# Altium schematic sheet 主控板A.SchDoc
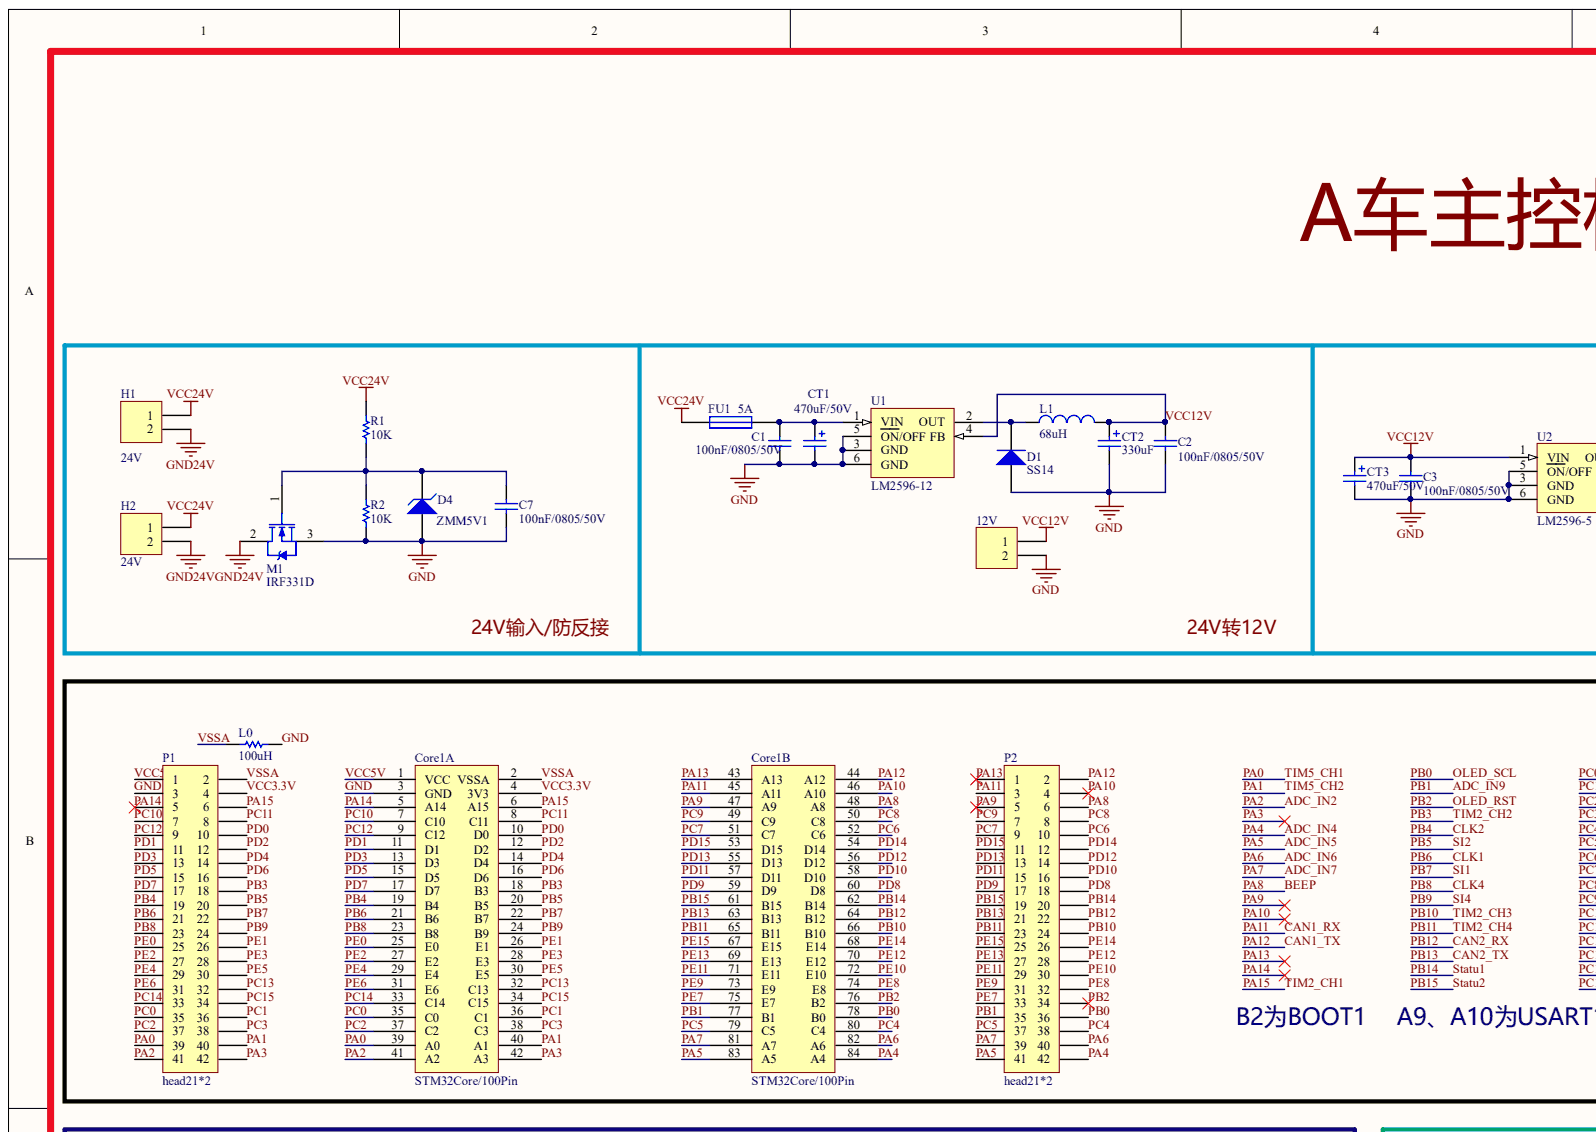
[diagram p0: 主控板A.SchDoc, top left]
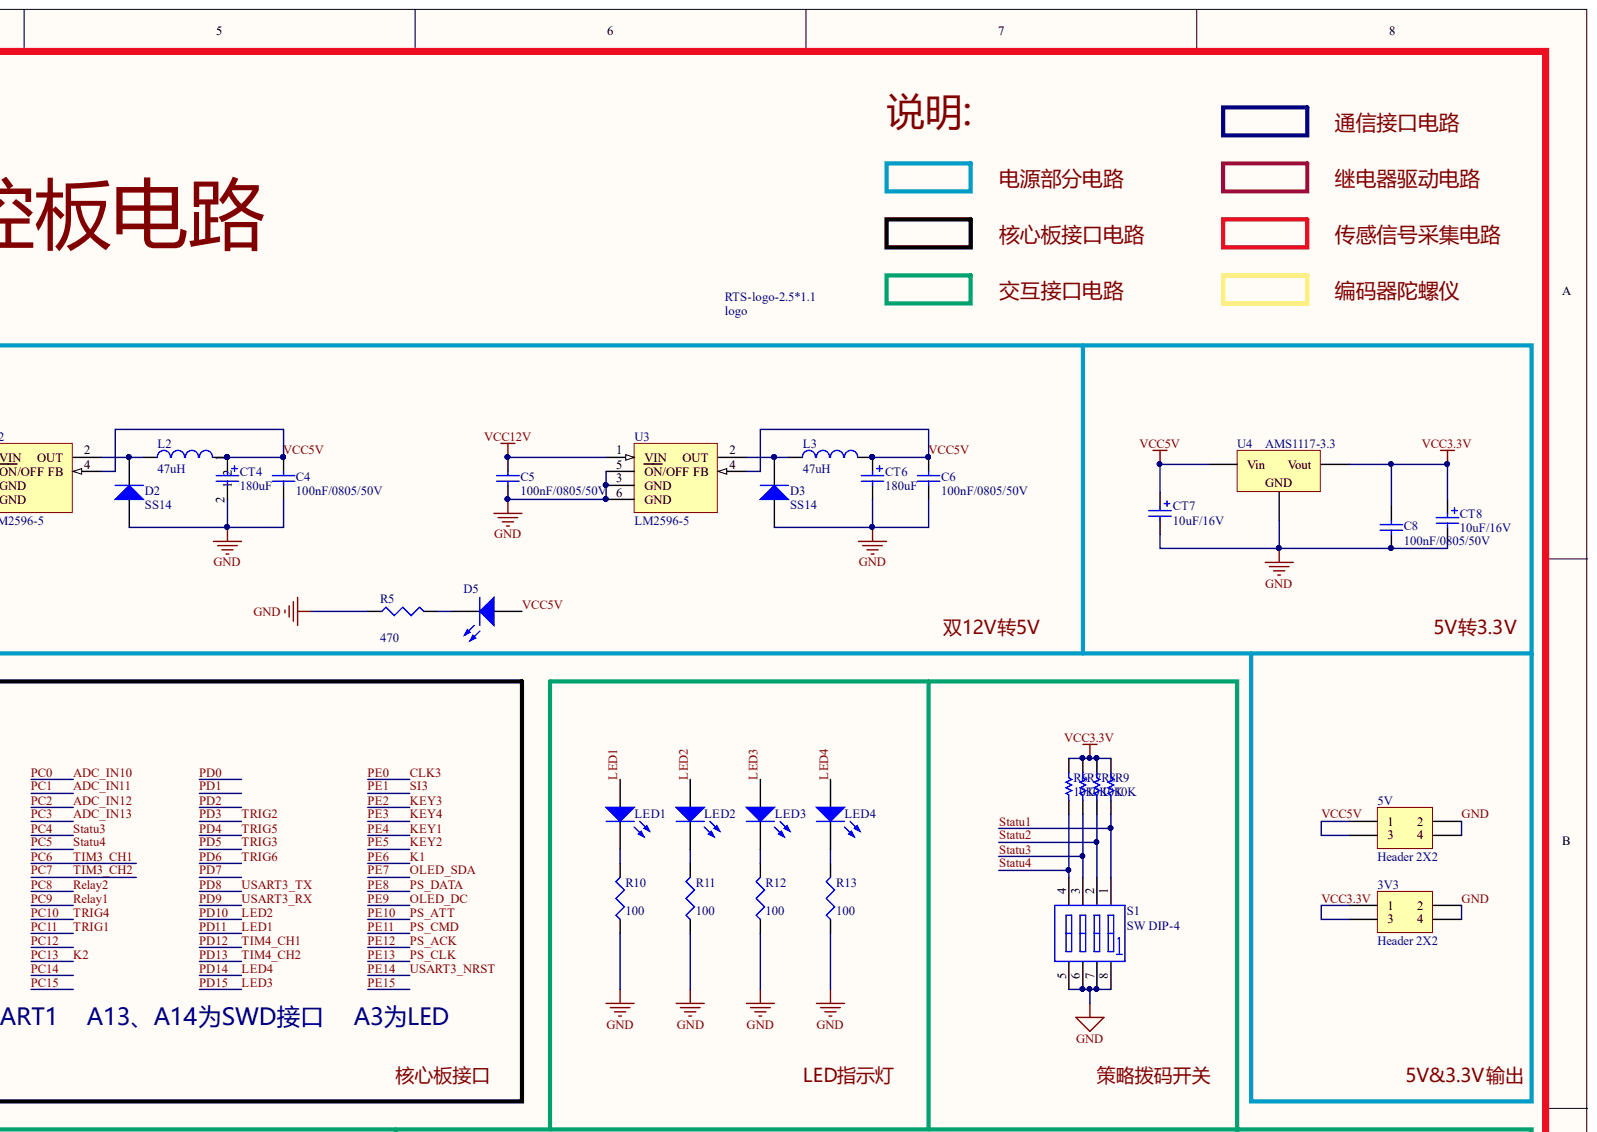
[diagram p1: 主控板A.SchDoc, top right]
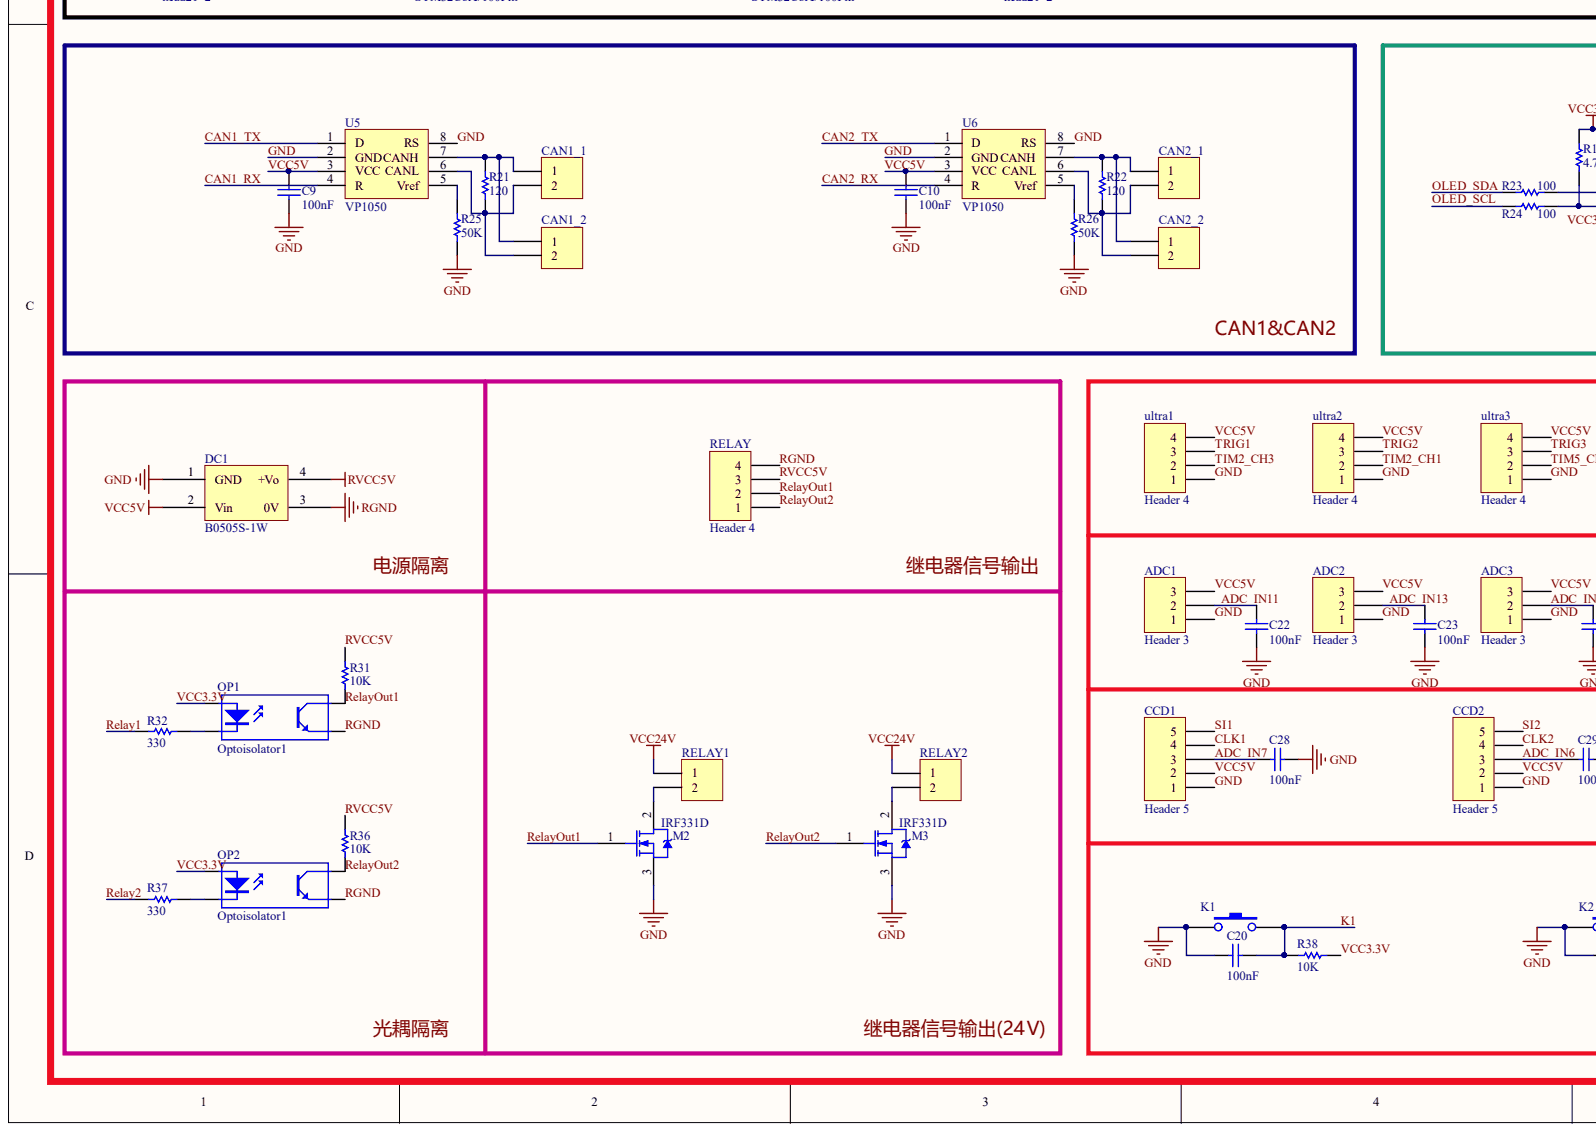
[diagram p2: 主控板A.SchDoc, bottom left]
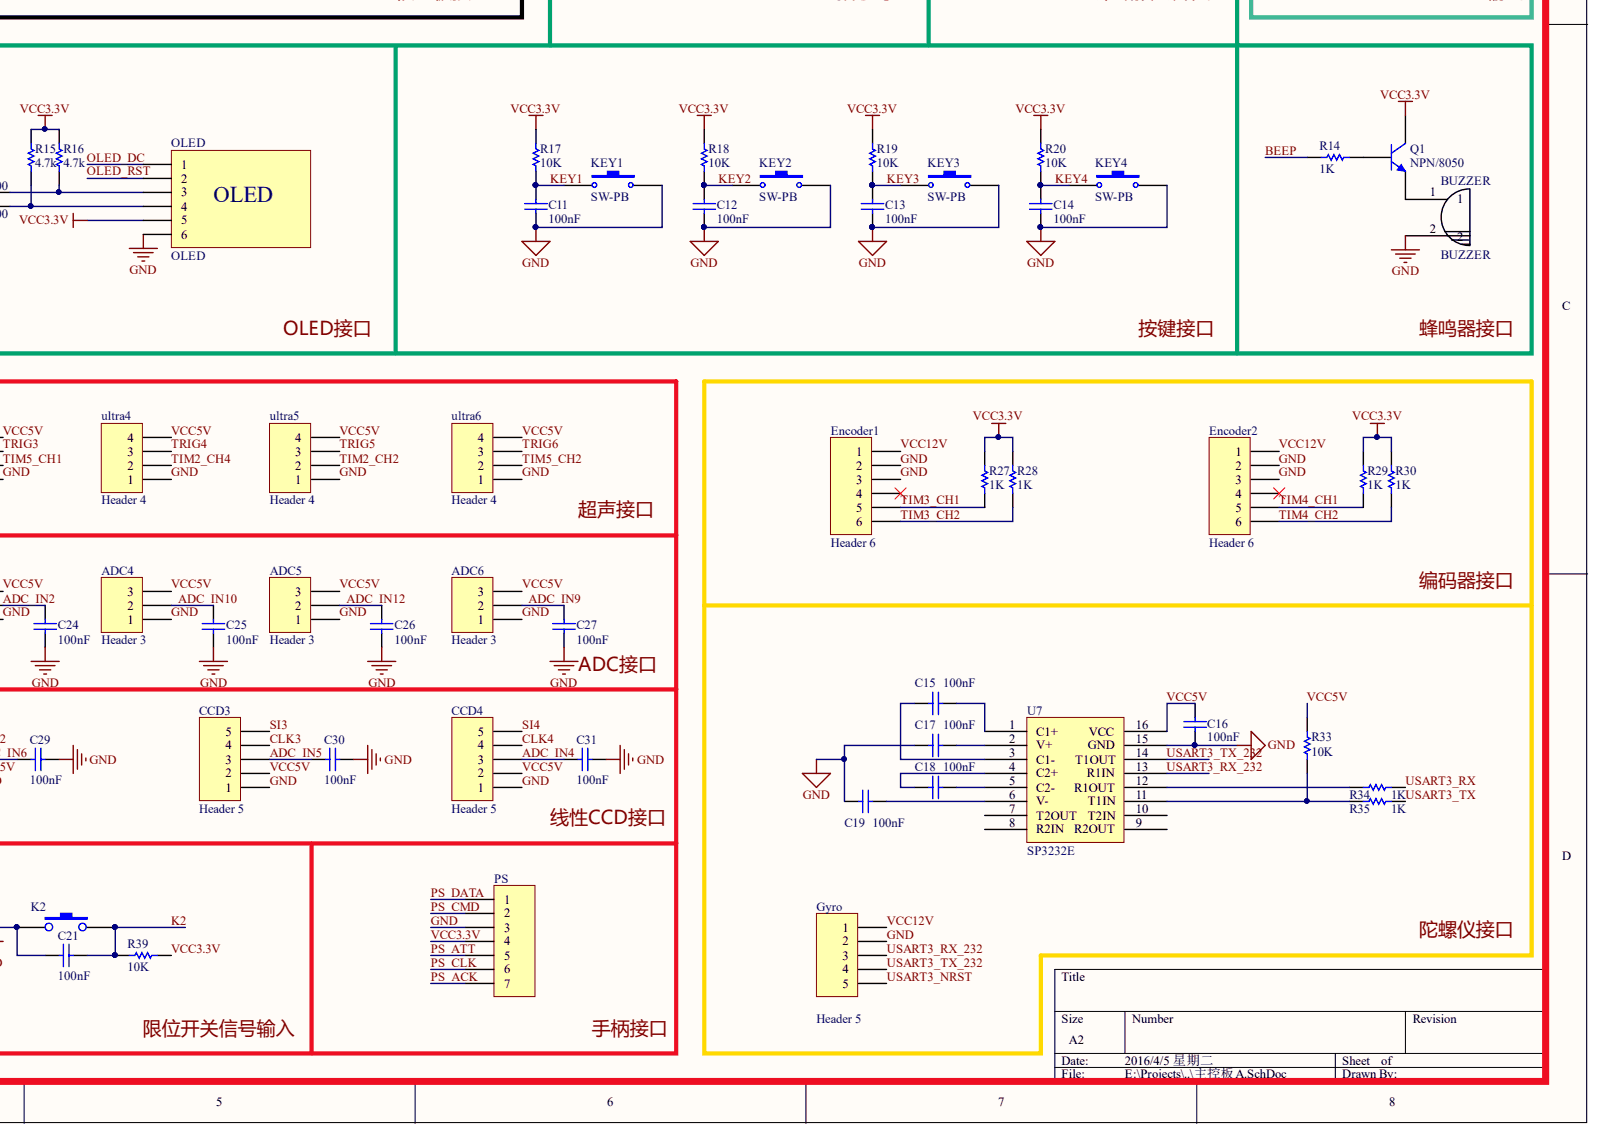
[diagram p3: 主控板A.SchDoc, bottom right]

## Parts
3V3  Header 2X2  [HDR2X2]
5V  Header 2X2  [HDR2X2]
12V  Header 2  [7555]  (value not drawn)
ADC1  Header 3  [H3]
ADC2  Header 3  [H3]
ADC3  Header 3  [H3]
ADC4  Header 3  [H3]
ADC5  Header 3  [H3]
ADC6  Header 3  [H3]
BUZZER  BUZZER  [BUZZER_DIP]
C1  100nF/0805/50V  [0805C]
C2  100nF/0805/50V  [0805C]
C3  100nF/0805/50V  [0805C]
C4  100nF/0805/50V  [0805C]
C5  100nF/0805/50V  [0805C]
C6  100nF/0805/50V  [0805C]
C7  100nF/0805/50V  [0805C]
C8  100nF/0805/50V  [0805C]
C9  100nF  [0805C]
C10  100nF  [0805C]
C11  value 100nF  [0805C]  (hidden comment: Cap)
C12  value 100nF  [0805C]  (hidden comment: Cap)
C13  value 100nF  [0805C]  (hidden comment: Cap)
C14  value 100nF  [0805C]  (hidden comment: Cap)
C15  value 100nF  [0805C]  (hidden comment: Cap)
C16  value 100nF  [0805C]  (hidden comment: Cap)
C17  value 100nF  [0805C]  (hidden comment: Cap)
C18  value 100nF  [0805C]  (hidden comment: Cap)
C19  value 100nF  [0805C]  (hidden comment: Cap)
C20  100nF  [0805C]
C21  100nF  [0805C]
C22  100nF  [0805C]
C23  100nF  [0805C]
C24  100nF  [0805C]
C25  100nF  [0805C]
C26  100nF  [0805C]
C27  100nF  [0805C]
C28  100nF  [0805C]
C29  100nF  [0805C]
C30  100nF  [0805C]
C31  100nF  [0805C]
CAN1_1  Header 2  [H2]  (value not drawn)
CAN1_2  Header 2  [H2]  (value not drawn)
CAN2_1  Header 2  [H2]  (value not drawn)
CAN2_2  Header 2  [H2]  (value not drawn)
CCD1  Header 5  [H5]
CCD2  Header 5  [H5]
CCD3  Header 5  [H5]
CCD4  Header 5  [H5]
Core1  STM32Core/100Pin  [Core]  (parts A,B)
CT1  470uF/50V  [LV_Cap8*8mm]
CT2  330uF  [LV_Cap8*8mm]
CT3  470uF/50V  [LV_Cap8*8mm]
CT4  180uF  [LV_Cap8*8mm]
CT6  180uF  [LV_Cap8*8mm]
CT7  10uF/16V  [0805C]
CT8  10uF/16V  [0805C]
D1  SS14  [SS12-SS100/SMA]
D2  SS14  [SS12-SS100/SMA]
D3  SS14  [SS12-SS100/SMA]
D4  ZMM5V1  [ZMM2V4-ZMM75]
D5  (no value)  [LED0805]
DC1  B0505S-1W  [B_S-1W]
Encoder1  Header 6  [H6]
Encoder2  Header 6  [H6]
FU1  value 5A  [UF500]  (hidden comment: FUSE)
Gyro  Header 5  [H5]
H1  24V  [7555]
H2  24V  [7555]
K1  RESET  [HDR1X2]  (value not drawn)
K2  RESET  [HDR1X2]  (value not drawn)
KEY1  SW-PB  [KEY_SMD]
KEY2  SW-PB  [KEY_SMD]
KEY3  SW-PB  [KEY_SMD]
KEY4  SW-PB  [KEY_SMD]
L0  100uH  [0805R]
L1  value 68uH  [INDC2_100UH]  (hidden comment: Inductor)
L2  value 47uH  [INDC2_100UH]  (hidden comment: Inductor)
L3  value 47uH  [INDC2_100UH]  (hidden comment: Inductor)
LED1  (no value)  [LED0805]
LED2  (no value)  [LED0805]
LED3  (no value)  [LED0805]
LED4  (no value)  [LED0805]
logo  RTSlogo2.5*1.1  [logo_2.5*1.1]
M1  IRF331D  [TO263]
M2  IRF331D  [IRLR7843]
M3  IRF331D  [IRLR7843]
OLED  OLED  [OLED]
OP1  Optoisolator1  [G3VM-61D1]
OP2  Optoisolator1  [G3VM-61D1]
P1  head21*2  [head21*2]
P2  head21*2  [head21*2]
PS  Header 7  [H7]  (value not drawn)
Q1  NPN/8050  [SOT-23_L]
R1  10K  [0805R]
R2  10K  [0805R]
R5  value 470  [0805R]
R6  10K  [0805R]
R7  10K  [0805R]
R8  10K  [0805R]
R9  10K  [0805R]
R10  value 100  [0805R]
R11  value 100  [0805R]
R12  value 100  [0805R]
R13  value 100  [0805R]
R14  1K  [0805R]
R15  4.7k  [0805R]
R16  4.7k  [0805R]
R17  value 10K  [0805R]  (hidden comment: Res1)
R18  value 10K  [0805R]  (hidden comment: Res1)
R19  value 10K  [0805R]  (hidden comment: Res1)
R20  value 10K  [0805R]  (hidden comment: Res1)
R21  120  [0805R]
R22  120  [0805R]
R23  100  [0805R]
R24  100  [0805R]
R25  50K  [0805R]
R26  50K  [0805R]
R27  1K  [0805R]
R28  1K  [0805R]
R29  1K  [0805R]
R30  1K  [0805R]
R31  10K  [0805R]
R32  330  [0805R]
R33  10K  [0805R]
R34  1K  [0805R]
R35  1K  [0805R]
R36  10K  [0805R]
R37  330  [0805R]
R38  10K  [0805R]
R39  10K  [0805R]
RELAY  Header 4  [H4]
RELAY1  Header 2  [7555]  (value not drawn)
RELAY2  Header 2  [7555]  (value not drawn)
S1  SW DIP-4  [BOMA]
U1  LM2596-12  [LM2596]
U2  LM2596-5  [LM2596]
U3  LM2596-5  [LM2596]
U4  AMS1117-3.3  [SOT223]
U5  VP1050  [SO8M]
U6  VP1050  [SO8M]
U7  SP3232E  [SOP16 MAX232]
ultra1  Header 4  [H4]
ultra2  Header 4  [H4]
ultra3  Header 4  [H4]
ultra4  Header 4  [H4]
ultra5  Header 4  [H4]
ultra6  Header 4  [H4]

## Nets
GND24V: H1.2 H2.2 M1.2
VCC24V: FU1.1 H1.1 H2.1 R1.2 RELAY1.1 RELAY2.1
ADC_IN2: ADC3.2 C24.2 Core1.41(A2) P1.41
ADC_IN4: C31.2 CCD4.3 Core1.84(A4) P2.42
ADC_IN5: C30.2 CCD3.3 Core1.83(A5) P2.41
ADC_IN6: C29.2 CCD2.3 Core1.82(A6) P2.40
ADC_IN7: C28.2 CCD1.3 Core1.81(A7) P2.39
ADC_IN9: ADC6.2 C27.2 Core1.77(B1) P2.35
ADC_IN10: ADC4.2 C25.2 Core1.35(C0) P1.35
ADC_IN11: ADC1.2 C22.2 Core1.36(C1) P1.36
ADC_IN12: ADC5.2 C26.2 Core1.37(C2) P1.37
ADC_IN13: ADC2.2 C23.2 Core1.38(C3) P1.38
BEEP: Core1.48(A8) P2.6 R14.1
CAN1_RX: Core1.45(A11) P2.3 U5.4(R)
CAN1_TX: Core1.44(A12) P2.2 U5.1(D)
CAN2_RX: Core1.64(B12) P2.22 U6.4(R)
CAN2_TX: Core1.63(B13) P2.21 U6.1(D)
CLK1: CCD1.4 Core1.21(B6) P1.21
CLK2: CCD2.4 Core1.19(B4) P1.19
CLK3: CCD3.4 Core1.25(E0) P1.25
CLK4: CCD4.4 Core1.23(B8) P1.23
GND: 3V3.2 3V3.4 5V.2 5V.4 12V.2 ADC1.1 ADC2.1 ADC3.1 ADC4.1 ADC5.1 ADC6.1 BUZZER.2 C1.1 C2.1 C3.1 C4.1 C5.1 C6.1 C7.1 C8.1 C9.1 C10.1 C11.1 C12.1 C13.1 C14.1 C16.1 C17.2 C19.2 C20.1 C21.1 C22.1 C23.1 C24.1 C25.1 C26.1 C27.1 C28.1 C29.1 C30.1 C31.1 CCD1.1 CCD2.1 CCD3.1 CCD4.1 Core1.3(GND) CT1.2 CT2.2 CT3.2 CT4.2 CT6.2 CT7.2 CT8.2 D1.1 D2.1 D3.1 D4.1 DC1.1(GND) Encoder1.2 Encoder1.3 Encoder2.2 Encoder2.3 Gyro.2 K1.1 K2.1 KEY1.2 KEY2.2 KEY3.2 KEY4.2 L0.1 M1.3 M2.3 M3.3 OLED.6 P1.3 PS.3 R2.1 R5.1 R10.1 R11.1 R12.1 R13.1 R25.1 R26.1 S1.5 S1.6 S1.7 S1.8 U1.3(GND) U1.5(ON/OFF) U1.6(GND) U2.3(GND) U2.5(ON/OFF) U2.6(GND) U3.3(GND) U3.5(ON/OFF) U3.6(GND) U4.1 U5.2(GND) U5.8(RS) U6.2(GND) U6.8(RS) U7.15(GND) ultra1.1 ultra2.1 ultra3.1 ultra4.1 ultra5.1 ultra6.1
K1: C20.2 Core1.31(E6) K1.2 P1.31 R38.1
K2: C21.2 Core1.32(C13) K2.2 P1.32 R39.1
KEY1: C11.2 Core1.29(E4) KEY1.1 P1.29 R17.2
KEY2: C12.2 Core1.30(E5) KEY2.1 P1.30 R18.2
KEY3: C13.2 Core1.27(E2) KEY3.1 P1.27 R19.2
KEY4: C14.2 Core1.28(E3) KEY4.1 P1.28 R20.2
LED1: Core1.57(D11) LED1.1 P2.15
LED2: Core1.58(D10) LED2.1 P2.16
LED3: Core1.53(D15) LED3.1 P2.11
LED4: Core1.54(D14) LED4.1 P2.12
OLED_DC: Core1.73(E9) OLED.1 P2.31
OLED_RST: Core1.76(B2) OLED.2 P2.34
OLED_SCL: Core1.78(B0) P2.36 R24.2
OLED_SDA: Core1.75(E7) P2.33 R23.2
PA0: Core1.39(A0) P1.39 ultra3.2
PA1: Core1.40(A1) P1.40 ultra6.2
PA3: Core1.42(A3) P1.42
PA9: Core1.47(A9) P2.5
PA10: Core1.46(A10) P2.4
PA13: Core1.43(A13) P2.1
PA14: Core1.5(A14) P1.5
PA15: Core1.6(A15) P1.6 ultra2.2
PB3: Core1.18(B3) P1.18 ultra5.2
PB5: CCD2.5 Core1.20(B5) P1.20
PB7: CCD1.5 Core1.22(B7) P1.22
PB9: CCD4.5 Core1.24(B9) P1.24
PB10: Core1.66(B10) P2.24 ultra1.2
PB11: Core1.65(B11) P2.23 ultra4.2
PB14: Core1.62(B14) P2.20 R9.2 S1.1
PB15: Core1.61(B15) P2.19 R8.2 S1.2
PC4: Core1.80(C4) P2.38 R7.2 S1.3
PC5: Core1.79(C5) P2.37 R6.2 S1.4
PC6: Core1.52(C6) Encoder1.5 P2.10 R27.2
PC7: Core1.51(C7) Encoder1.6 P2.9 R28.2
PC8: Core1.50(C8) P2.8 R37.2
PC9: Core1.49(C9) P2.7 R32.2
PC10: Core1.7(C10) P1.7 ultra4.3
PC11: Core1.8(C11) P1.8 ultra1.3
PC12: Core1.9(C12) P1.9
PC14: Core1.33(C14) P1.33
PC15: Core1.34(C15) P1.34
PD0: Core1.10(D0) P1.10
PD1: Core1.11(D1) P1.11
PD2: Core1.12(D2) P1.12
PD3: Core1.13(D3) P1.13 ultra2.3
PD4: Core1.14(D4) P1.14 ultra5.3
PD5: Core1.15(D5) P1.15 ultra3.3
PD6: Core1.16(D6) P1.16 ultra6.3
PD7: Core1.17(D7) P1.17
PD8: Core1.60(D8) P2.18 R35.1
PD9: Core1.59(D9) P2.17 R34.1
PD12: Core1.56(D12) Encoder2.5 P2.14 R29.2
PD13: Core1.55(D13) Encoder2.6 P2.13 R30.2
PE1: CCD3.5 Core1.26(E1) P1.26
PE8: Core1.74(E8) P2.32 PS.1
PE10: Core1.72(E10) P2.30 PS.5
PE11: Core1.71(E11) P2.29 PS.2
PE12: Core1.70(E12) P2.28 PS.7
PE13: Core1.69(E13) P2.27 PS.6
PE14: Core1.68(E14) Gyro.5 P2.26
PE15: Core1.67(E15) P2.25
RELAYOUT1: M2.1 OP1.4 R31.2 RELAY.2
RELAYOUT2: M3.1 OP2.4 R36.2 RELAY.1
RGND: DC1.3(0V) OP1.3 OP2.3 RELAY.4
RVCC5V: DC1.4(+Vo) R31.1 R36.1 RELAY.3
USART3_RX_232: Gyro.3 U7.13(R1IN)
USART3_TX_232: Gyro.4 U7.14(T1OUT)
VCC3.3V: 3V3.1 3V3.3 C8.2 Core1.4(3V3) CT8.1 OLED.5 OP1.1 OP2.1 P1.4 PS.4 Q1.1 R6.1 R7.1 R8.1 R9.1 R15.2 R16.2 R17.1 R18.1 R19.1 R20.1 R27.1 R28.1 R29.1 R30.1 R38.2 R39.2 U4.2
VCC5V: 5V.1 5V.3 ADC1.3 ADC2.3 ADC3.3 ADC4.3 ADC5.3 ADC6.3 C4.2 C6.2 C9.2 C10.2 C16.2 CCD1.2 CCD2.2 CCD3.2 CCD4.2 Core1.1(VCC) CT4.1 CT6.1 CT7.1 D5.1 DC1.2(Vin) L2.2 L3.2 P1.1 R33.1 U2.4(FB) U3.4(FB) U4.3 U5.3(VCC) U6.3(VCC) U7.16(VCC) ultra1.4 ultra2.4 ultra3.4 ultra4.4 ultra5.4 ultra6.4
VCC12V: 12V.1 C2.2 C3.2 C5.2 CT2.1 CT3.1 Encoder1.1 Encoder2.1 Gyro.1 L1.2 U1.4(FB) U2.1(VIN) U3.1(VIN)
VSSA: Core1.2(VSSA) L0.2 P1.2
NetBUZZER_1: BUZZER.1 Q1.3
NetC1_2: C1.2 CT1.1 FU1.2 U1.1(VIN)
NetC7_2: C7.2 D4.2 M1.1 R1.1 R2.2
NetC15_1: C15.1 U7.1(C1+)
NetC15_2: C15.2 U7.3(C1-)
NetC17_1: C17.1 U7.2(V+)
NetC18_1: C18.1 U7.5(C2-)
NetC18_2: C18.2 U7.4(C2+)
NetC19_1: C19.1 U7.6(V-)
NetCAN1_1_1: CAN1_1.1 CAN1_2.1 R21.2 U5.7(CANH)
NetCAN1_1_2: CAN1_1.2 CAN1_2.2 R21.1 U5.6(CANL)
NetCAN2_1_1: CAN2_1.1 CAN2_2.1 R22.2 U6.7(CANH)
NetCAN2_1_2: CAN2_1.2 CAN2_2.2 R22.1 U6.6(CANL)
NetD1_2: D1.2 L1.1 U1.2(OUT)
NetD2_2: D2.2 L2.1 U2.2(OUT)
NetD3_2: D3.2 L3.1 U3.2(OUT)
NetD5_2: D5.2 R5.2
NetLED1_2: LED1.2 R10.2
NetLED2_2: LED2.2 R11.2
NetLED3_2: LED3.2 R12.2
NetLED4_2: LED4.2 R13.2
NetM2_2: M2.2 RELAY1.2
NetM3_2: M3.2 RELAY2.2
NetOLED_3: OLED.3 R16.1 R23.1
NetOLED_4: OLED.4 R15.1 R24.1
NetOP1_2: OP1.2 R32.1
NetOP2_2: OP2.2 R37.1
NetQ1_2: Q1.2 R14.2
NetR25_2: R25.2 U5.5(Vref)
NetR26_2: R26.2 U6.5(Vref)
NetR33_2: R33.2 R35.2 U7.11(T1IN)
NetR34_2: R34.2 U7.12(R1OUT)

Not connected: Encoder1 4; Encoder2 4; U7 7(T2OUT) 8(R2IN) 9(R2OUT) 10(T2IN)

## Library data of the parts
3V3 5V = Header 2X2: Header, 2-Pin, Dual row; Component Kind: Standard; LatestRevisionDate: 17-Jul-2002; LatestRevisionNote: Re-released for DXP Platform.; Library Name: Miscellaneous Connectors.IntLib; Signal Integrity: Connector; Publisher: Altium Limited
12V CAN1_1 CAN1_2 CAN2_1 CAN2_2 H1 H2 RELAY1 RELAY2 = Header 2: Header, 2-Pin; Component Kind: Standard; LatestRevisionDate: 17-Jul-2002; LatestRevisionNote: Re-released for DXP Platform.; Signal Integrity: Connector; Publisher: Altium Limited
  12V H1 H2 RELAY1 RELAY2: Library Name: My_Library.SchLib
  CAN1_1 CAN1_2 CAN2_1 CAN2_2: Library Name: Miscellaneous Connectors.IntLib
ADC1-ADC6 = Header 3: Header, 3-Pin; Component Kind: Standard; LatestRevisionDate: 17-Jul-2002; LatestRevisionNote: Re-released for DXP Platform.; Library Name: Miscellaneous Connectors.IntLib; Signal Integrity: Connector; Publisher: Altium Limited
C1-C10 C20-C31 = CAP: Capacitor; Value: 100pF; Component Kind: Standard; Published: 8-Jun-2000; Revision: July-2002: Re-released for DXP Platform.; Publisher: Altium Limited
  C1-C8: Library Name: My_Library.SchLib
C11-C19 = Cap: Capacitor; Component Kind: Standard; LatestRevisionDate: 17-Jul-2002; LatestRevisionNote: Re-released for DXP Platform.; Library Name: Miscellaneous Devices.IntLib; PackageDescription: Radial Cap, Thru-Hole; 2 Leads; 0.3 in Pin Spacing; PackageReference: RAD-0.3; Published: 8-Jun-2000; Publisher: Altium Limited
CCD1-CCD4 Gyro = Header 5: Header, 5-Pin; Component Kind: Standard; LatestRevisionDate: 17-Jul-2002; LatestRevisionNote: Re-released for DXP Platform.; Library Name: Miscellaneous Connectors.IntLib; Signal Integrity: Connector; Publisher: Altium Limited
Core1 = Core: Component Kind: Standard; Library Name: Schlib1.SchLib
CT1-CT4 CT6-CT8 = Cap Pol1: Polarized Capacitor (Radial); Value: 100uF; Component Kind: Standard; LatestRevisionDate: 17-Jul-2002; LatestRevisionNote: Re-released for DXP Platform.; Library Name: My_Library.SchLib; PackageReference: RB7.6-15; Published: 8-Jun-2000; Signal Integrity: Cap Pol; Publisher: Altium Limited
D1-D3 = Diode: 1 Amp General Purpose Rectifier; Code_JEDEC: DO-204-AL; Component Kind: Standard; ComponentLink1Description: Manufacturer Link; ComponentLink1URL: http://www.fairchildsemi.com/; ComponentLink2Description: Datasheet; ComponentLink2URL: http://www.fairchildsemi.com/ds/1N%2F1N4001.pdf; DatasheetVersion: 1998; LatestRevisionDate: 17-Jul-2002; LatestRevisionNote: Re-released for DXP Platform.; Library Name: My_Library.SchLib; PackageReference: DO-41; PackageVersion: Aug-1999; Published: 8-Jun-2000; Publisher: Altium Limited
D4 = D Zener: Zener Diode; Component Kind: Standard; LatestRevisionDate: 17-Jul-2002; LatestRevisionNote: Re-released for DXP Platform.; Library Name: Miscellaneous Devices.IntLib; PackageDescription: Diode, Thru-Hole; 2 Leads; 0.7 in Pin Spacing; PackageReference: DIODE-0.7; Published: 8-Jun-2000; Publisher: Altium Limited
D5 LED1-LED4 = LED3: Typical BLUE SiC LED; Component Kind: Standard; DatasheetVersion: 16-Apr-2002; LatestRevisionDate: 17-Dec-2003; LatestRevisionNote: PCB Footprint replaced.; Library Name: Miscellaneous Devices.IntLib; PackageDescription: SM LED; 2 C-Bend Leads; Body 3.5 x 2.8 x 1.9 mm, inc leads (LxWxH typ); PackageReference: 3.5X2.8X1.9; PackageVersion: 16-Apr-2002; Published: 8-Jun-2000; Signal Integrity: LED; Simulation: LED3; Publisher: Altium Limited
Encoder1 Encoder2 = Header 6: Header, 6-Pin; Component Kind: Standard; LatestRevisionDate: 17-Jul-2002; LatestRevisionNote: Re-released for DXP Platform.; Library Name: Miscellaneous Connectors.IntLib; Signal Integrity: Connector; Publisher: Altium Limited
K1 K2 = SW-PB: Component Kind: Standard
KEY1-KEY4 = SW-PB: Switch; Component Kind: Standard; LatestRevisionDate: 17-Jul-2002; LatestRevisionNote: Re-released for DXP Platform.; Library Name: Miscellaneous Devices.IntLib; PackageReference: SPST-2; Published: 24-Mar-1999; Publisher: Altium Limited
L0 R6-R9 R15 R16 R21-R26 R31 R32 R36-R39 = res1: Resistor; Value: 1K; Component Kind: Standard; Published: 8-Jun-2000; Revision: July-2002: Re-released for DXP Platform.; Publisher: Altium Limited
L1-L3 = Inductor: Code_IEC: 1005; Code_JEITA: 0402; Component Kind: Standard; Description: Inductor; LatestRevisionDate: 29-May-2009; LatestRevisionNote: IPC-7351 Footprint Added.; Library Name: My_Library.SchLib; PackageDescription: Chip Inductor; PackageReference: 0402-A; Published: 8-Jun-2000; Publisher: Altium Limited
M1 = IRF3808S: 场效应管; Component Kind: Standard; Library Name: My_Library.SchLib
M2 M3 = IRF3808S: Component Kind: Standard; Library Name: BCLib.SCHLIB
OP1 OP2 = Optoisolator1: 4-Pin Phototransistor Optocoupler; Component Kind: Standard; DatasheetVersion: Nov-2003; LatestRevisionDate: 04-Mar-2005; LatestRevisionNote: Sch pins 3 & 4 Swapped.; Library Name: Miscellaneous Devices.IntLib; PackageReference: DIP-4; Published: 24-Mar-1999; Publisher: Altium Limited
PS = Header 7: Header, 7-Pin; Component Kind: Standard; LatestRevisionDate: 17-Jul-2002; LatestRevisionNote: Re-released for DXP Platform.; Library Name: Miscellaneous Connectors.IntLib; Signal Integrity: Connector; Publisher: Altium Limited
Q1 = NPN: NPN Bipolar Transistor; Code_JEDEC: TO-226-AA; Component Kind: Standard; LatestRevisionDate: 17-Jul-2002; LatestRevisionNote: Re-released for DXP Platform.; Library Name: Miscellaneous Devices.IntLib; PackageDescription: TO, Flat Index; 3 In-Line, Axial Leads; Body Dia. 4.8mm; Leads 0.55 x 0.51 mm (max); PackageReference: TO-226-AA; Published: 8-Jun-2000; Signal Integrity: NPN; Simulation: NPN; Publisher: Altium Limited
R1 R2 = RES: Resistor; Value: 1K; Component Kind: Standard; Library Name: My_Library.SchLib; Published: 8-Jun-2000; Revision: July-2002: Re-released for DXP Platform.; Publisher: Altium Limited
R5 R10-R13 = Res3: Resistor; Component Kind: Standard; LatestRevisionDate: 29-May-2009; LatestRevisionNote: IPC-7351 Footprint Added.; Library Name: Miscellaneous Devices.IntLib; PackageDescription: Chip Resistor; PackageReference: J1-0603; Published: 8-Jun-2000; Signal Integrity: Res; Simulation: RESISTOR; Publisher: Altium Limited
R14 = res1: Resistor; Component Kind: Standard; Published: 8-Jun-2000; Revision: July-2002: Re-released for DXP Platform.; Value: 1K; Publisher: Altium Limited
R17-R20 R33 = Res1: Resistor; Component Kind: Standard; LatestRevisionDate: 17-Jul-2002; LatestRevisionNote: Re-released for DXP Platform.; Library Name: Miscellaneous Devices.IntLib; PackageReference: AXIAL-0.3; Published: 8-Jun-2000; Publisher: Altium Limited
  R33: Value: 10K
R27-R30 R34 R35 = Res1: Resistor; Value: 10K; Component Kind: Standard; LatestRevisionDate: 17-Jul-2002; LatestRevisionNote: Re-released for DXP Platform.; Library Name: Miscellaneous Devices.IntLib; PackageReference: AXIAL-0.3; Published: 8-Jun-2000; Publisher: Altium Limited
RELAY ultra1-ultra6 = Header 4: Header, 4-Pin; Component Kind: Standard; LatestRevisionDate: 17-Jul-2002; LatestRevisionNote: Re-released for DXP Platform.; Library Name: Miscellaneous Connectors.IntLib; Signal Integrity: Connector; Publisher: Altium Limited
S1 = SW DIP-4: DIP Switch, 4 Position, SPST; Code_IPC: SOIC127P600-8; Code_JEDEC: MS-012-AA; Component Kind: Standard; LatestRevisionDate: 29-May-2009; LatestRevisionNote: IPC-7351 Footprint Added.; Library Name: Miscellaneous Devices.IntLib; PackageDescription: 8-Pin Small Outline Integrated Circuit 1.27 mm Pitch; PackageReference: SO8; PackageVersion: Dec-2002; Published: 24-Mar-1999; Simulation: dpsw4; Publisher: Altium Limited
U1-U3 = LM2576D2T-ADJ: Easy Switcher 3.0A Step-Down Voltage Regulator; Note: Pin 3 is electrically connected to Pin 6;Pin 6 is heatsink; Component Kind: Standard; ComponentLink1Description: Manufacturer Link; ComponentLink1URL: http://www.onsemi.com/site/products/taxonomy/0,4438,503,00.html; ComponentLink2Description: Datasheet; ComponentLink2URL: http://www.onsemi.com/pub/Collateral/LM2576-D.PDF; DatasheetVersion: Jul-1999; Library Name: My_Library.SchLib; PackageDescription: D2-PAK; 5 Leads; Body 14.7 (inc. leads) x 10.2 mm (LxW max); PackageReference: 936A-02; PackageVersion: Jul-1999; Published: 15-Apr-2002; Publisher: Altium Limited
U4 = Volt Reg: Voltage Regulator; Component Kind: Standard; LatestRevisionDate: 29-May-2009; LatestRevisionNote: IPC-7351 Footprint Added.; Library Name: MainBoard.SCHLIB; PackageDescription: 3-Pin Transistor Outline Package 2.54 mm Pitch, 15.1 mm Lead Span; PackageReference: D2PAK; Published: 24-Mar-1999; Publisher: Altium Limited
U5 U6 = SN65HVD230: Component Kind: Standard
BUZZER = BUZZER: Component Kind: Standard; Description: buzzer; Library Name: My_Library.SchLib
DC1 = B0505S-1W: Component Kind: Standard; Library Name: My_Library.SchLib
FU1 = FUSE: Component Kind: Standard; Description: Fuse; Library Name: My_Library.SchLib
logo = RTSlogo2.5*1.1: Component Kind: Standard; Library Name: logo.SchLib
OLED = OLED: Component Kind: Standard; Library Name: Sony1.SCHLIB
P1 P2 = head21*2: Component Kind: Standard; Library Name: Schlib1.SchLib
U7 = SP3232E: Component Kind: Standard; Library Name: MainPCB.SchLib
